# Altium schematic sheet 006_SoCPower.SchDoc(006_SoCPower)
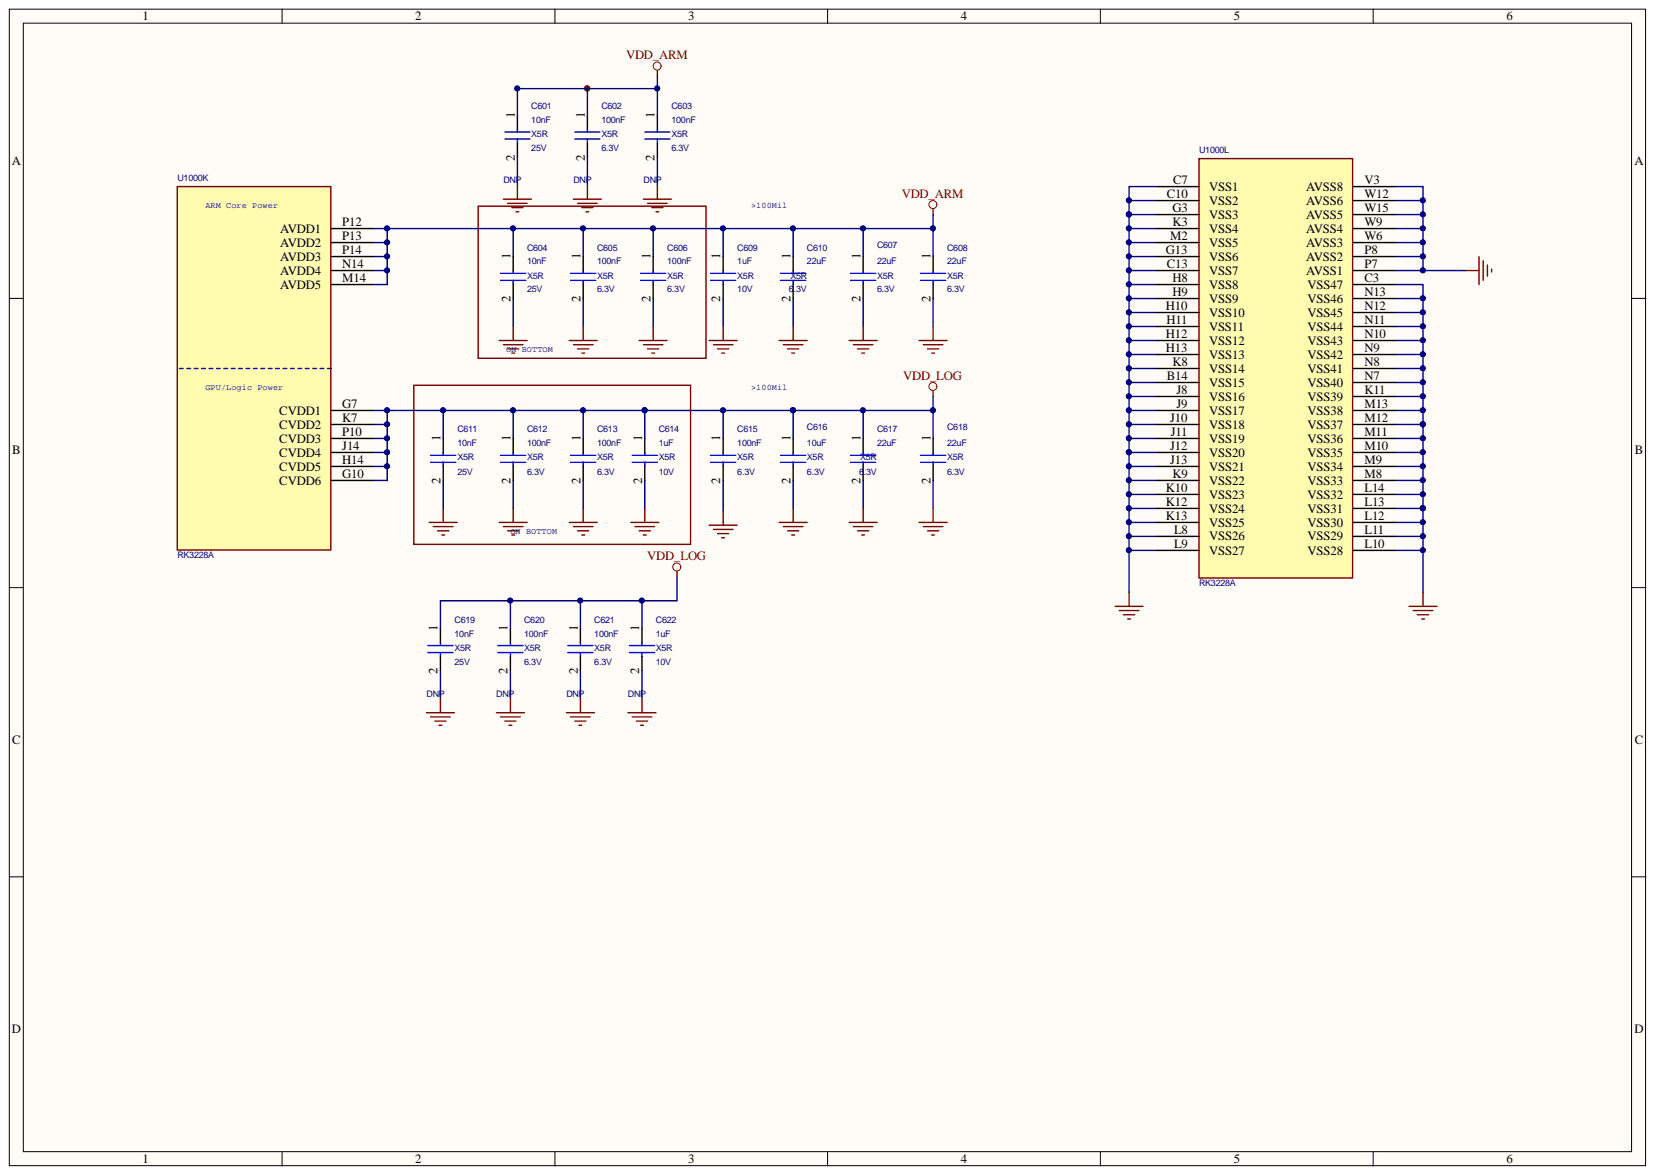
[diagram p0: 006_SoCPower.SchDoc]

## Parts
C601  10nF  Voltage: 25V  option: DNP  Dielectric: X5R  [C0402]
C602  100nF  Voltage: 6.3V  option: DNP  Dielectric: X5R  [C0402]
C603  100nF  Voltage: 6.3V  option: DNP  Dielectric: X5R  [C0402]
C604  10nF  Voltage: 25V  Dielectric: X5R  [C0402]
C605  100nF  Voltage: 6.3V  Dielectric: X5R  [C0402]
C606  100nF  Voltage: 6.3V  Dielectric: X5R  [C0402]
C607  22uF  Voltage: 6.3V  Dielectric: X5R  [C0603]
C608  22uF  Voltage: 6.3V  Dielectric: X5R  [C0603]
C609  1uF  Voltage: 10V  Dielectric: X5R  [C0402]
C610  22uF  Voltage: 6.3V  Dielectric: X5R  [C0603]
C611  10nF  Voltage: 25V  Dielectric: X5R  [C0402]
C612  100nF  Voltage: 6.3V  Dielectric: X5R  [C0402]
C613  100nF  Voltage: 6.3V  Dielectric: X5R  [C0402]
C614  1uF  Voltage: 10V  Dielectric: X5R  [C0402]
C615  100nF  Voltage: 6.3V  Dielectric: X5R  [C0402]
C616  10uF  Voltage: 6.3V  Dielectric: X5R  [C0603]
C617  22uF  Voltage: 6.3V  Dielectric: X5R  [C0603]
C618  22uF  Voltage: 6.3V  Dielectric: X5R  [C0603]
C619  10nF  Voltage: 25V  option: DNP  Dielectric: X5R  [C0402]
C620  100nF  Voltage: 6.3V  option: DNP  Dielectric: X5R  [C0402]
C621  100nF  Voltage: 6.3V  option: DNP  Dielectric: X5R  [C0402]
C622  1uF  Voltage: 10V  option: DNP  Dielectric: X5R  [C0402]
U1000  RK3228A  [BGA316-14X14_0.65]  (parts K,L)

## Nets
GND: C601.2 C602.2 C603.2 C604.2 C605.2 C606.2 C607.2 C608.2 C609.2 C610.2 C611.2 C612.2 C613.2 C614.2 C615.2 C616.2 C617.2 C618.2 C619.2 C620.2 C621.2 C622.2 U1000.B14(VSS15) U1000.C3(VSS47) U1000.C7(VSS1) U1000.C10(VSS2) U1000.C13(VSS7) U1000.G3(VSS3) U1000.G13(VSS6) U1000.H8(VSS8) U1000.H9(VSS9) U1000.H10(VSS10) U1000.H11(VSS11) U1000.H12(VSS12) U1000.H13(VSS13) U1000.J8(VSS16) U1000.J9(VSS17) U1000.J10(VSS18) U1000.J11(VSS19) U1000.J12(VSS20) U1000.J13(VSS21) U1000.K3(VSS4) U1000.K8(VSS14) U1000.K9(VSS22) U1000.K10(VSS23) U1000.K11(VSS39) U1000.K12(VSS24) U1000.K13(VSS25) U1000.L8(VSS26) U1000.L9(VSS27) U1000.L10(VSS28) U1000.L11(VSS29) U1000.L12(VSS30) U1000.L13(VSS31) U1000.L14(VSS32) U1000.M2(VSS5) U1000.M8(VSS33) U1000.M9(VSS34) U1000.M10(VSS35) U1000.M11(VSS36) U1000.M12(VSS37) U1000.M13(VSS38) U1000.N7(VSS40) U1000.N8(VSS41) U1000.N9(VSS42) U1000.N10(VSS43) U1000.N11(VSS44) U1000.N12(VSS45) U1000.N13(VSS46) U1000.P7(AVSS1) U1000.P8(AVSS2) U1000.V3(AVSS8) U1000.W6(AVSS3) U1000.W9(AVSS4) U1000.W12(AVSS6) U1000.W15(AVSS5)
VDD_ARM: C601.1 C602.1 C603.1 C604.1 C605.1 C606.1 C607.1 C608.1 C609.1 C610.1 U1000.M14(AVDD5) U1000.N14(AVDD4) U1000.P12(AVDD1) U1000.P13(AVDD2) U1000.P14(AVDD3)
VDD_LOG: C611.1 C612.1 C613.1 C614.1 C615.1 C616.1 C617.1 C618.1 C619.1 C620.1 C621.1 C622.1 U1000.G7(CVDD1) U1000.G10(CVDD6) U1000.H14(CVDD5) U1000.J14(CVDD4) U1000.K7(CVDD2) U1000.P10(CVDD3)

## Library data of the parts
C601 C604 C611 C619 = CAP NP_Dup5: cap,10.00nF,+/-10%,25V,X5R,C0402; Manufacturer: TDK; RK PN: C1005X5R1E103K050BA; Priority: A; Tolerance: +/-10%; Part Type: C0402; Manufacturer PN: C1005X5R1E103K050BA; Component Kind: Standard; C_Voltage: 25V; Created by: JJJ
C602 C603 C605 C606 C612 C613 C615 C620 C621 = CAP NP_Dup5: cap,100.00nF,+/-10%,6.3V,X5R,C0402; Manufacturer: TDK; Tolerance: 10%; RK PN: C1005X5R0J104K050BA; Priority: A; Number: 37; Part Type: C0402; Manufacturer PN: C1005X5R0J104K050BA; Component Kind: Standard; C_Voltage: 6.3V; Created by: JJJ
C607 C608 C610 C617 C618 = CAP NP_Dup5: cap,22.00uF,+/-20%,6.3V,X5R,C0603; Manufacturer: TDK; RK PN: C1608X5R0J226M080AC; Priority: A; Tolerance: +/-20%; Part Type: C0603; Manufacturer PN: C1608X5R0J226M080AC; Component Kind: Standard; C_Voltage: 6.3V; Created by: JJJ
C609 C614 C622 = CAP NP_Dup5: cap,1.00uF,+/-10%,10V,X5R,C0402; Manufacturer: TDK; Tolerance: 10%; RK PN: C1005X5R1A105K050BB; Priority: A; Number: 37; C_Voltage: 6.3V; Part Type: C0402; Manufacturer PN: C1005X5R1A105K050BB; Component Kind: Standard; Created by: JJJ
C616 = CAP NP_Dup5: cap,10.00uF,+/-10%,6.3V,X5R,C0603; Manufacturer: TDK; Tolerance: 10%; RK PN: C1608X5R0J106K080AB; Priority: A; Part Type: C0603; Manufacturer PN: C1608X5R0J106K080AB; Component Kind: Standard; C_Voltage: 6.3V; Created by: JJJ
U1000 = DSP_RK3228: ROCKCHIP CPU; Manufacturer: Rockchip (쐉拱匣); RK PN: RK3228; Priority: A; Part Type: DSP; Manufacturer PN: RK3228; Component Kind: Standard; Created by: JJJ
